# Altium schematic sheet uController.SchDoc
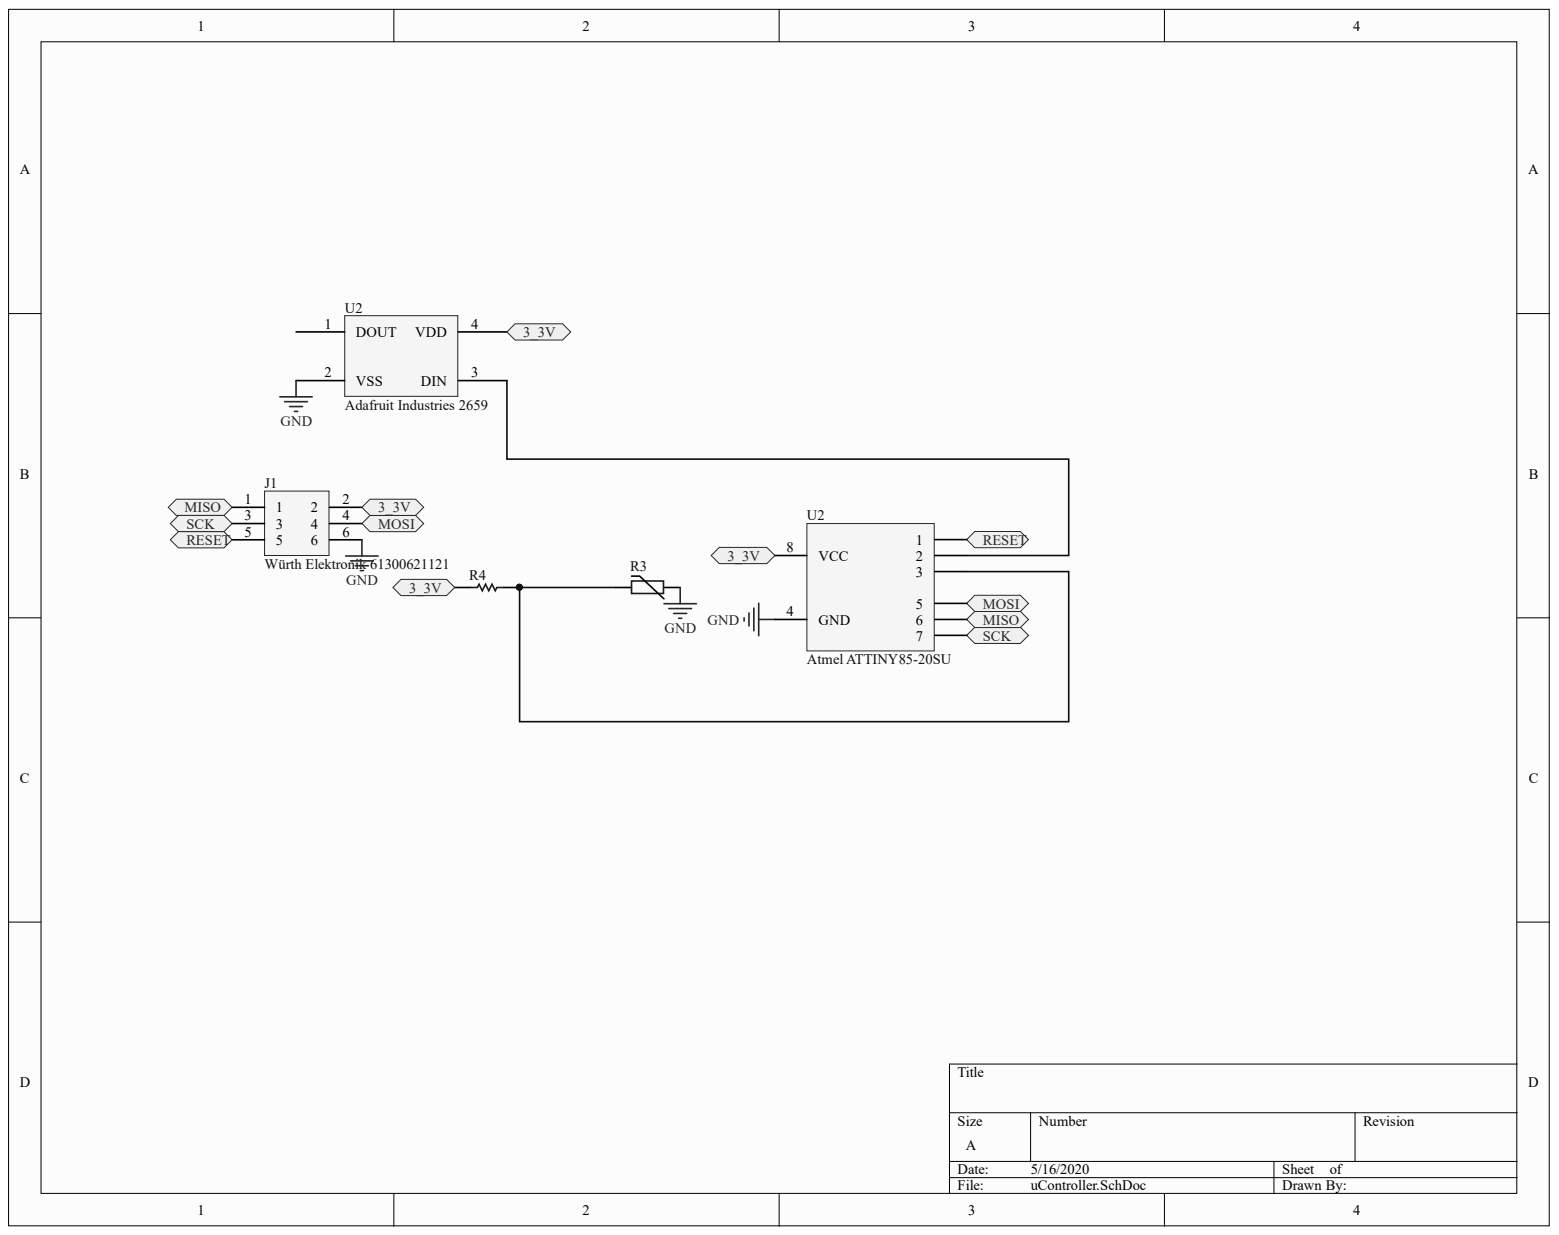
[diagram p0: uController.SchDoc]

## Parts
J1  Würth Elektronik 61300621121  Manufacturer Part Number: 61300621121  [PCB-zvoyfznuc9b5lzhitk0z-1]
R3  Vishay NTCS0603E3222FMT  [RESC1608X06N]  (value not drawn)
R4  Panasonic ERA-3YEB103V  [RESC1608X55X30NL20T20]  (value not drawn)
U2  Atmel ATTINY85-20SU  Manufacturer: Atmel  Manufacturer Part Number: ATTINY85-20SU  [SOIC127P800X216-8N]
U2  Adafruit Industries 2659  [PCB-2ttavi1bqi6b1gr71otv-1]

## Ports
3_3V, MISO, MOSI, RESET, SCK

## Nets
GND: J1.6 R3.2 U2.2 U2.4
3_3V: J1.2 R4.1 U2.4 U2.8(VCC) ; port 3_3V
MISO: J1.1 U2.6 ; port MISO
MOSI: J1.4 U2.5 ; port MOSI
RESET: J1.5 U2.1 ; port RESET
SCK: J1.3 U2.7 ; port SCK
NetR3_1: R3.1 R4.2 U2.3
NetU2_2: U2.2 U2.3

## Library data of the parts
J1 = CMP-8aac4253fa23556b-5: THT Vertical Pin Header WR-PHD, Pitch 2.54 mm, Dual Row, 6 pins; Current Rating: 3.00 A; Gender: Male; Number of Contacts: 6; Color: Black; Component Kind: Standard; Contact Material: Copper; Contact Plating: Gold; Library Name: CommunityVault; Mounting Style: Through Hole; OctopartID: 8aac4253fa23556b; Octopart URL: https://octopart.com/61300621121-w%C3%BCrth+elektronik-32855529; Operating Temperature: -40.0 °C (min); REACH SVHC Compliance: No SVHC
R3 = CMP-3832f3b29fa3e7f5-1: Thermistor NTC 2.2K Ohm 1% 2-Pin 0603 Surface Mount Solder Pad 3520K -4 to -3.5 T/R Automotive; Power Dissipation: 125 mW; Resistance: 2.20 k?; Case/Package: 0603; Component Kind: Standard; Library Name: CommunityVault; Manufacturer Part Number: NTCS0603E3222FMT; Mounting Style: Surface Mount; OctopartID: 3832f3b29fa3e7f5; Octopart URL: https://octopart.com/ntcs0603e3222fmt-vishay-42631927; Operating Temperature: -40.0 °C (min); REACH SVHC Compliance: No SVHC; Resistance Tolerance: ±1%; RoHS: Compliant
R4 = CMP-3718261-2: Metal Film Resistors 10K   OHM  .1% 25PPM; Lead-Free Status: Lead Free; Power Rating: 0.063 W; Resistance: 10000.0 Ω; Resistance Tolerance: ±0.1%; Voltage Rating (DC): 75.0 V; Component Kind: Standard; Library Name: CommunityVault; Lifecycle Status: Not Listed by Manufacturer; Manufacturer Part Number: ERA-3YEB103V; Mounting Style: Surface Mount; OctopartID: b58c7fcbf3056a4b; Octopart Url: https://octopart.com/era-3yeb103v-panasonic-55403503; Packaging: Cut Tape (CT); RoHS: Compliant; Size-Height: 0.00045 m; Size-Length: 0.0016 m; Size-Width: 0.0008 m
U2 = CMP-0093-00173-7: Vendor Other Part Number: ATTINY85-20SU-ND; Connectivity: USI; Core Processor: AVR; Core Size: 8-Bit; Data Converters: A/D 4x10b; EEPROM Size: 512 x 8; Expanded Description: AVR AVR® ATtiny Microcontroller IC 8-Bit 20MHz 8KB (4K x 16) FLASH 8-SOIC; Number of I/O: 6; Oscillator Type: Internal; Peripherals: Brown-out Detect/Reset, POR, PWM, WDT; Program Memory Size: 8KB (4K x 16); Program Memory Type: FLASH; Speed: 20MHz; Vendor: Verical; Vendor Other: Digikey; Voltage - Supply (Vcc/Vdd): 2.7 V ~ 5.5 V; Category: Integrated Circuits (ICs); Component Kind: Standard; Description: Atmel ATTINY85-20SU; Family: Embedded - Microcontrollers; Library Name: FavoritesLibrary; OctopartID: 4ed985889323911e; Octopart URL: http://octopart.com/attiny85-20su-atmel-39581852; Operating Temperature: -40°C ~ 85°C (TA); Package / Case: 8-SOIC (0.209", 5.30mm Width); RAM Size: 512 x 8; Series: AVR® ATtiny; Supplier Device Package: 8-SOIC; Vendor Part Number: ATTINY85-20SU
U2 = CMP-9c52515654ae4395-2: Neopixel Mini 3535 Rgb Leds W/ Integrated Driver Chip - White - Pack Of 10; Component Kind: Standard; Library Name: CommunityVault
